# Altium schematic sheet EIT_Accel.SchDoc
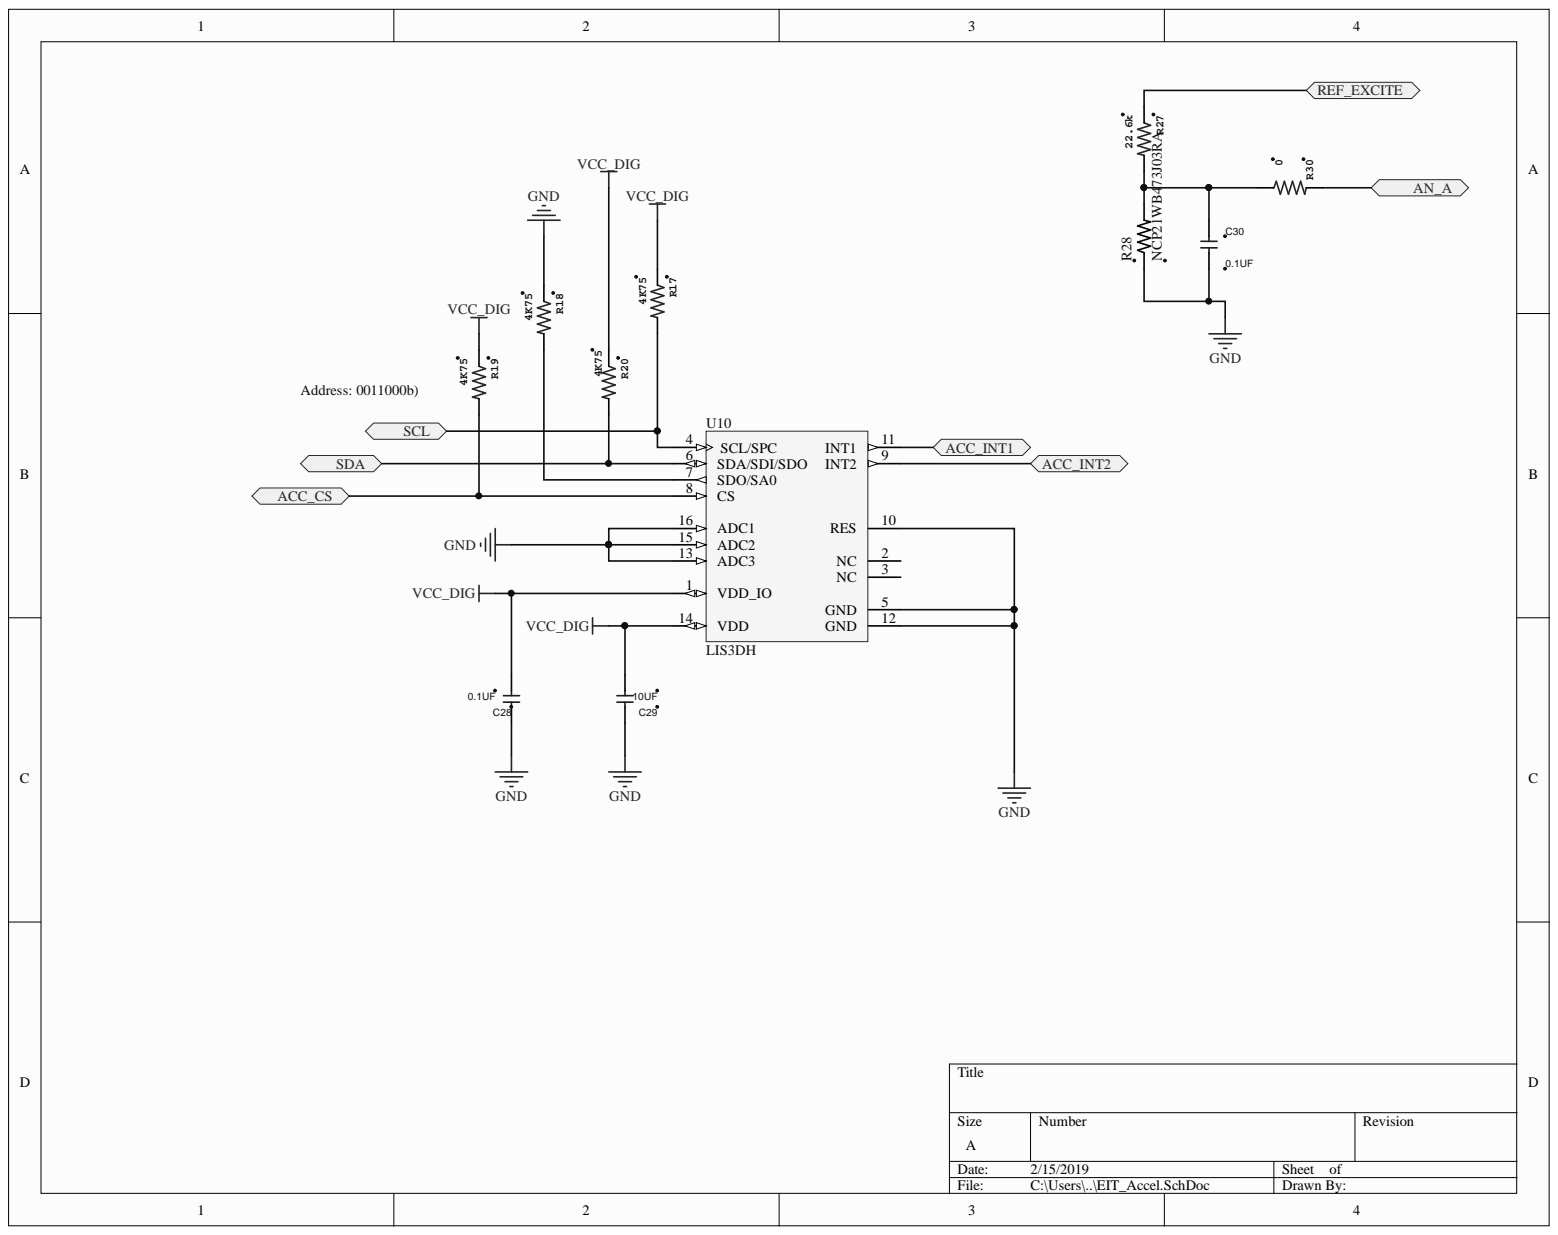
[diagram p0: EIT_Accel.SchDoc]

## Parts
C28  0.1UF  [CAP 0402/1005]
C29  10UF  [CAP 0402/1005]
C30  0.1UF  [CAP 0402/1005]
R17  4K75  [RES_1608X55]
R18  4K75  [RES_1608X55]
R19  4K75  [RES_1608X55]
R20  4K75  [RES_1608X55]
R27  22.6k  [RES_1608X55]
R28  NCP21WB473J03RA  [CAPC2012X135N]
R30  0  [RES_1608X55]
U10  LIS3DH  [LGA16-3X3X1_N]

## Ports
ACC_CS, ACC_INT1, ACC_INT2, AN_A, REF_EXCITE, SCL, SDA

## Nets
GND: C28.2 C29.2 C30.1 R18.2 R28.1 U10.5(GND) U10.10(RES) U10.12(GND) U10.13(ADC3) U10.15(ADC2) U10.16(ADC1)
VCC_DIG: C28.1 C29.1 R17.2 R19.2 R20.2 U10.1(VDD_IO) U10.14(VDD)
ACC_CS: R19.1 U10.8(CS) ; port ACC_CS
ACC_INT1: U10.11(INT1) ; port ACC_INT1
ACC_INT2: U10.9(INT2) ; port ACC_INT2
AN_A: R30.1 ; port AN_A
REF_EXCITE: R27.2 ; port REF_EXCITE
SCL: R17.1 U10.4(SCL/SPC) ; port SCL
SDA: R20.1 U10.6(SDA/SDI/SDO) ; port SDA
NetC30_2: C30.2 R27.1 R28.2 R30.2
NetR18_1: R18.1 U10.7(SDO/SA0)

Not connected: U10 2(NC) 3(NC)

## Library data of the parts
C28 C30 = CAP_0402_0.1UF: CAP CER 0.1UF 4V X5R 0402 10% tolerance; Manufacturer 1: KEMET; Manufacturer Part Number 1: C0402C104K7PAC7867; Vendor: DIGIKEY; Component Kind: Standard; Supplier 1: Digi-Key; Supplier Part Number 1: 399-14871-1-ND; Value: 0.1UF; Library Name: EIT_lib.IntLib
C29 = CAP_0402_10UF: CAP CER 10UF 100V C0G 0402; Manufacturer 1: Taiyo Yuden; Manufacturer Part Number 1: AMK105CBJ106MV-F; Vendor: DIGIKEY; Component Kind: Standard; Supplier 1: Digi-Key; Supplier Part Number 1: 587-3237-1-ND; Value: 10UF; Library Name: EIT_lib.IntLib
R17-R20 = RES_0603_4K75: 4k75Ohm RES_0603; Manufacturer 1: Yageo; Manufacturer Part Number 1: AC0603FR-074K75L; DeviceName: -0603(1608METRIC); DeviceSetName: RESISTOR-US; GateName_1: G$1; Component Kind: Standard; SymbolName_1: RESISTOR-US; Value: 4K75; Library Name: EIT_lib.IntLib
R27 = RES_0603_22k6: 22.6kOhm RES_0603; Manufacturer 1: Panasonic Electronic Components; Manufacturer Part Number 1: ERA-3AEB2262V; DeviceName: -0603(1608METRIC); DeviceSetName: RESISTOR-US; GateName_1: G$1; Component Kind: Standard; Supplier 1: Digi-Key; SymbolName_1: RESISTOR-US; Value: 22.6k; Library Name: EIT_lib.IntLib
R28 = NCP21WB473J03RA: Thermistor NTC 47K Ohm 5% 2-Pin 0805 Surface Mount Solder Pad 4108K T/R; Manufacturer 1: Murata; Component Kind: Standard; Digi-Key_Part_Number: 490-2450-1-ND; Digi-Key_Purchase_URL: https://www.digikey.com/product-detail/en/murata-electronics-north-america/NCP21WB473J03RA/490-2450-1-ND/588664?utm_source=snapeda&utm_medium=aggregator&utm_campaign=symbol; Manufacturer Part Number 1: NCP21WB473J03RA; MF: Murata; MP: NCP21WB473J03RA; Package: 0805 Murata; SnapEDA_Link: https://www.snapeda.com/parts/NCP21WB473J03RA/Murata%20Electronics%20North%20America/view-part/?ref=snap; Library Name: EIT_lib.IntLib
R30 = RES_0603_zero: Zero Ohm RES_0603; Manufacturer 1: Panasonic Electronic Components; Manufacturer Part Number 1: ERJ-3GEY0R00V; DeviceName: -0603(1608METRIC); DeviceSetName: RESISTOR-US; GateName_1: G$1; Component Kind: Standard; Supplier 1: Digi-Key; SymbolName_1: RESISTOR-US; Value: 0; Library Name: EIT_lib.SchLib
U10 = LIS3DH: MEMS digital output motion sensor: Ultra low-power high performance 3-axis nano accelerometer, -40 to +85°C, LGA-16 (3x3x1), Tray; Manufacturer: STMicroelectronics; Manufacturer Part Number 1: LIS3DHTR; Component Kind: Standard; ComponentLink1Description: Manufacturer URL; ComponentLink1URL: http://www.st.com/; ComponentLink2Description: LIS3DH Datasheet; ComponentLink2URL: http://www.st.com/internet/com/TECHNICAL_RESOURCES/TECHNICAL_LITERATURE/DATASHEET/CD00274221.pdf; ComponentLink3Description: LIS3DH Web Page; ComponentLink3URL: http://www.st.com/internet/analog/product/250725.jsp; PackageDescription: 16-Pin Land Grid Array, 3 x 3 x 1 mm Body, 0.5 mm Pitch; PackageReference: LGA16-3X3X1; PackageVersion: 2008; Library Name: EIT_lib.SchLib
